# Altium schematic sheet main sheet.SchDoc
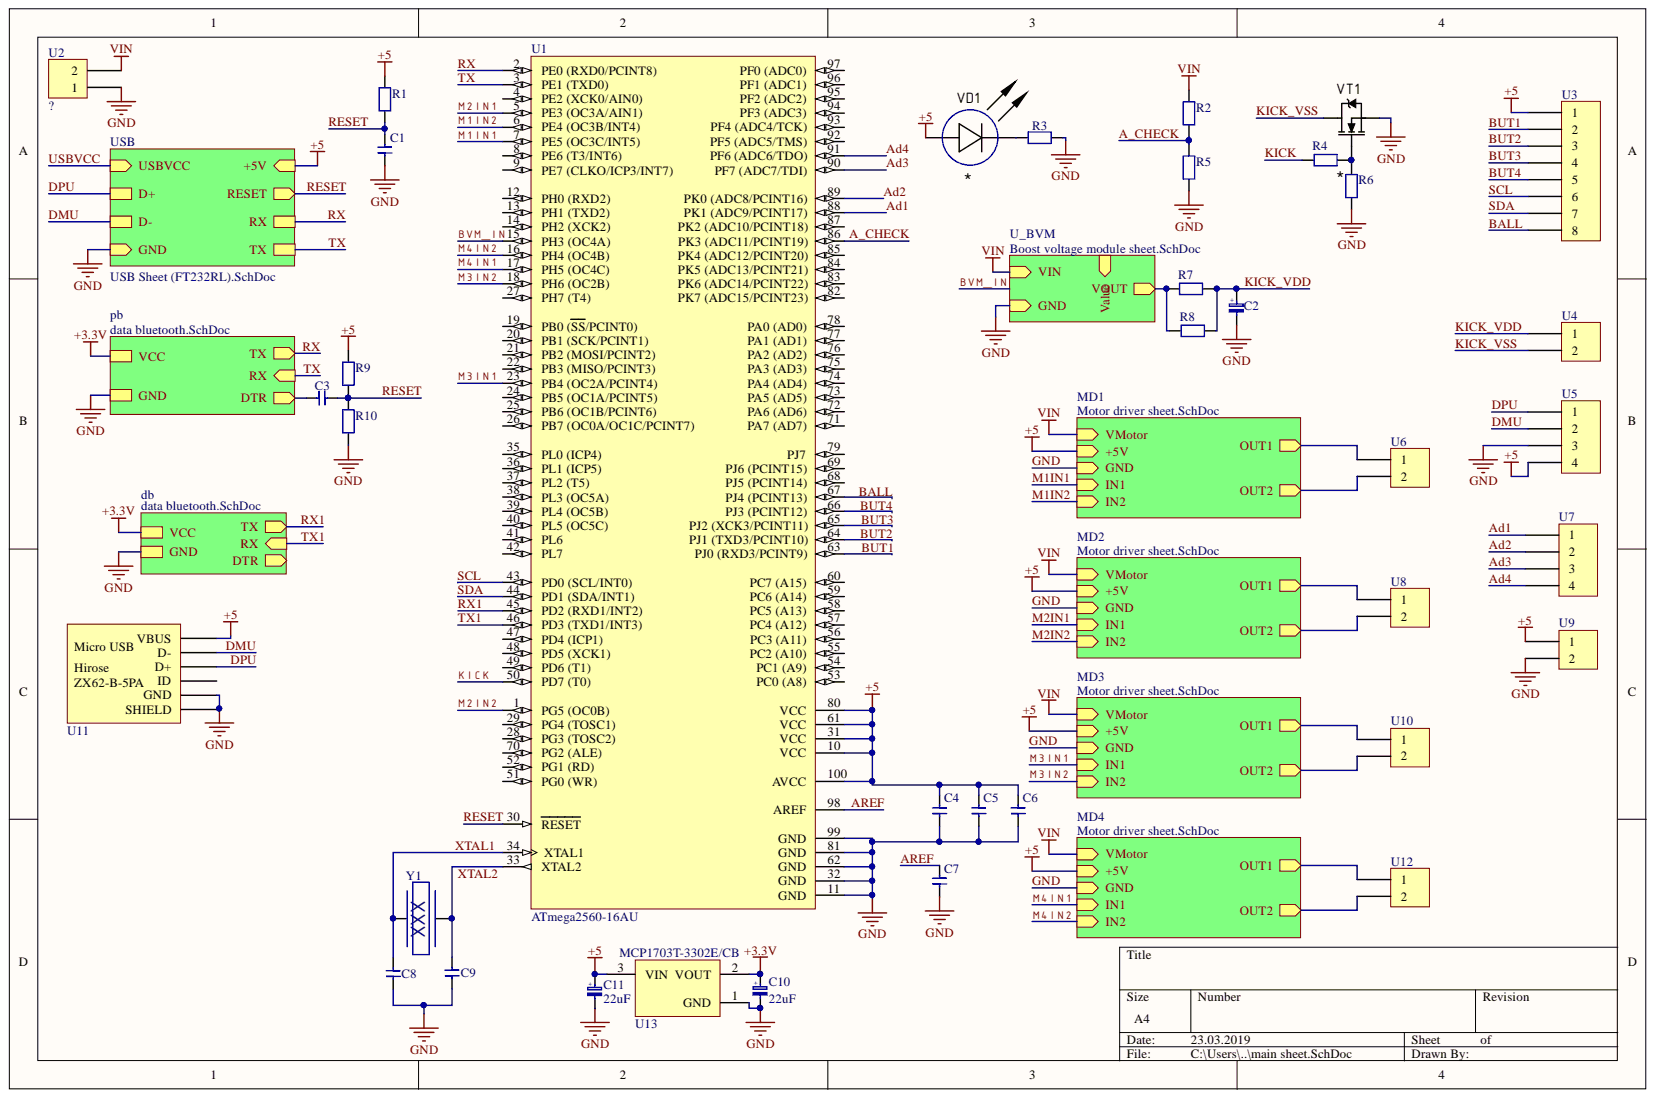
[diagram p0: main sheet.SchDoc]

## Parts
C1  =Value  [cap0603]
C2  =Value  [D18C7.5H40]
C3  =Value  [cap0603]
C4  =Value  [cap0603]
C5  =Value  [cap0603]
C6  =Value  [cap0603]
C7  =Value  [cap0603]
C8  =Value  [cap0603]
C9  =Value  [cap0603]
C10  22uF  [Tantal B]
C11  22uF  [Tantal B]
R1  =Value  [res0603]
R2  =Value  [res0805]
R3  =Value  [res0603]
R4  =Value  [res0603]
R5  =Value  [res0805]
R6  =Value  [res0603]
R7  =Value  [smd2512]
R8  =Value  [smd2512]
R9  =Value  [res0603]
R10  =Value  [res0603]
U1  ATmega2560-16AU  [100A_N]
U2  ?  [XT30]
U3  Header 8  [8x1.25]  (value not drawn)
U4  ?  [XT30]  (value not drawn)
U5  Header 4  [4x1.25]  (value not drawn)
U6  Header 2  [2x1.25]  (value not drawn)
U7  Header 4  [4x1.25]  (value not drawn)
U8  Header 2  [2x1.25]  (value not drawn)
U9  Header 2  [2x1.25]  (value not drawn)
U10  Header 2  [2x1.25]  (value not drawn)
U11  ZX62-B-5PA  [ZX62-B-5PA]
U12  Header 2  [2x1.25]  (value not drawn)
U13  MCP1703T-3302E/CB  [SOT-23A-CB3_N]
VD1  (no value)  [CH0603LB]
VT1  (no value)  [DPAK]
Y1  XXX  [QSMD3.2x2.5_A]

## Nets
+3.3V: C10.2 U13.2
+5: C4.2 C5.2 C6.2 C11.2 R1.2 R9.2 U1.10(VCC) U1.31(VCC) U1.61(VCC) U1.80(VCC) U1.100(AVCC) U3.1 U5.4 U9.1 U11.1(VBUS) U13.3 VD1.1
VIN: R2.2 U2.2
A_CHECK: R2.1 R5.2 U1.86(PK3_(ADC11/PCINT19))
Ad1: U1.88(PK1_(ADC9/PCINT17)) U7.1
Ad2: U1.89(PK0_(ADC8/PCINT16)) U7.2
Ad3: U1.90(PF7_(ADC7/TDI)) U7.3
Ad4: U1.91(PF6_(ADC6/TDO)) U7.4
AREF: C7.2 U1.98(AREF)
BALL: U1.67(PJ4_(PCINT13)) U3.8
BUT1: U1.63(PJ0_(RXD3/PCINT9)) U3.2
BUT2: U1.64(PJ1_(TXD3/PCINT10)) U3.3
BUT3: U1.65(PJ2_(XCK3/PCINT11)) U3.4
BUT4: U1.66(PJ3_(PCINT12)) U3.5
BVM_IN: U1.15(PH3_(OC4A))
DMU: U5.2 U11.2(D-)
DPU: U5.1 U11.3(D+)
GND: C1.1 C2.2 C4.1 C5.1 C6.1 C7.1 C8.1 C9.1 C10.1 C11.1 R3.2 R5.1 R6.1 R10.1 U1.11(GND) U1.32(GND) U1.62(GND) U1.81(GND) U1.99(GND) U2.1 U5.3 U9.2 U11.5(GND) U11.6(SHIELD) U13.1 VT1.3
KICK: R4.1 U1.50(PD7_(T0))
KICK_VDD: C2.1 R7.2 R8.2 U4.1
KICK_VSS: U4.2 VT1.2
M1IN1: U1.7(PE5_(OC3C/INT5))
M1IN2: U1.6(PE4_(OC3B/INT4))
M2IN1: U1.5(PE3_(OC3A/AIN1))
M2IN2: U1.1(PG5_(OC0B))
M3IN1: U1.23(PB4_(OC2A/PCINT4))
M3IN2: U1.18(PH6_(OC2B))
M4IN1: U1.17(PH5_(OC4C))
M4IN2: U1.16(PH4_(OC4B))
RESET: C1.2 C3.2 R1.1 R9.1 R10.2 U1.30(RESET)
RX: U1.2(PE0_(RXD0/PCINT8))
RX1: U1.45(PD2_(RXD1/INT2))
SCL: U1.43(PD0_(SCL/INT0)) U3.6
SDA: U1.44(PD1_(SDA/INT1)) U3.7
TX: U1.3(PE1_(TXD0))
TX1: U1.46(PD3_(TXD1/INT3))
XTAL1: C8.2 U1.34(XTAL1) Y1.1
XTAL2: C9.2 U1.33(XTAL2) Y1.2
NetC3_1: C3.1
NetR3_1: R3.1 VD1.2
NetR4_2: R4.2 R6.2 VT1.1
NetR7_1: R7.1 R8.1
NetU1_4: U1.4(PE2_(XCK0/AIN0))
NetU1_8: U1.8(PE6_(T3/INT6))
NetU1_9: U1.9(PE7_(CLKO/ICP3/INT7))
NetU1_12: U1.12(PH0_(RXD2))
NetU1_13: U1.13(PH1_(TXD2))
NetU1_14: U1.14(PH2_(XCK2))
NetU1_19: U1.19(PB0_(SS/PCINT0))
NetU1_20: U1.20(PB1_(SCK/PCINT1))
NetU1_21: U1.21(PB2_(MOSI/PCINT2))
NetU1_22: U1.22(PB3_(MISO/PCINT3))
NetU1_24: U1.24(PB5_(OC1A/PCINT5))
NetU1_25: U1.25(PB6_(OC1B/PCINT6))
NetU1_26: U1.26(PB7_(OC0A/OC1C/PCINT7))
NetU1_27: U1.27(PH7_(T4))
NetU1_28: U1.28(PG3_(TOSC2))
NetU1_29: U1.29(PG4_(TOSC1))
NetU1_35: U1.35(PL0_(ICP4))
NetU1_36: U1.36(PL1_(ICP5))
NetU1_37: U1.37(PL2_(T5))
NetU1_38: U1.38(PL3_(OC5A))
NetU1_39: U1.39(PL4_(OC5B))
NetU1_40: U1.40(PL5_(OC5C))
NetU1_41: U1.41(PL6)
NetU1_42: U1.42(PL7)
NetU1_47: U1.47(PD4_(ICP1))
NetU1_48: U1.48(PD5_(XCK1))
NetU1_49: U1.49(PD6_(T1))
NetU1_51: U1.51(PG0_(WR))
NetU1_52: U1.52(PG1_(RD))
NetU1_53: U1.53(PC0_(A8))
NetU1_54: U1.54(PC1_(A9))
NetU1_55: U1.55(PC2_(A10))
NetU1_56: U1.56(PC3_(A11))
NetU1_57: U1.57(PC4_(A12))
NetU1_58: U1.58(PC5_(A13))
NetU1_59: U1.59(PC6_(A14))
NetU1_60: U1.60(PC7_(A15))
NetU1_68: U1.68(PJ5_(PCINT14))
NetU1_69: U1.69(PJ6_(PCINT15))
NetU1_70: U1.70(PG2_(ALE))
NetU1_71: U1.71(PA7_(AD7))
NetU1_72: U1.72(PA6_(AD6))
NetU1_73: U1.73(PA5_(AD5))
NetU1_74: U1.74(PA4_(AD4))
NetU1_75: U1.75(PA3_(AD3))
NetU1_76: U1.76(PA2_(AD2))
NetU1_77: U1.77(PA1_(AD1))
NetU1_78: U1.78(PA0_(AD0))
NetU1_79: U1.79(PJ7)
NetU1_82: U1.82(PK7_(ADC15/PCINT23))
NetU1_83: U1.83(PK6_(ADC14/PCINT22))
NetU1_84: U1.84(PK5_(ADC13/PCINT21))
NetU1_85: U1.85(PK4_(ADC12/PCINT20))
NetU1_87: U1.87(PK2_(ADC10/PCINT18))
NetU1_92: U1.92(PF5_(ADC5/TMS))
NetU1_93: U1.93(PF4_(ADC4/TCK))
NetU1_94: U1.94(PF3_(ADC3))
NetU1_95: U1.95(PF2_(ADC2))
NetU1_96: U1.96(PF1_(ADC1))
NetU1_97: U1.97(PF0_(ADC0))
NetU6_1: U6.1
NetU6_2: U6.2
NetU8_1: U8.1
NetU8_2: U8.2
NetU10_1: U10.1
NetU10_2: U10.2
NetU11_4: U11.4(ID)
NetU12_1: U12.1
NetU12_2: U12.2
DTR:
USBVCC:
Value:

## Library data of the parts
C1 C3-C9 = Cap SMD: Component Kind: Standard; Library Name: AlexChistiakov.SchLib
C2 = Cap Aluminum: Component Kind: Standard; Library Name: AlexChistiakov.SchLib
C10 C11 = Cap Tantal: Component Kind: Standard; Library Name: Discrete smd.SchLib
R1-R10 = Resistor SMD: Component Kind: Standard; Library Name: AlexChistiakov.SchLib
U1 = ATmega2560-16AU: 8-bit AVR Microcontroller, 4.5-5.5V, 256KB Flash, 4KB EEPROM, 8KB SRAM, 86 GPIO pins, 100-pin TQFP, Industrial Grade (-40°C to 85°C), Pb-Free; Code_JEDEC: MS-026-AED; Component Kind: Standard; ComponentLink1Description: Manufacturer Link; ComponentLink1URL: http://www.atmel.com/products/avr/default.asp?; ComponentLink2Description: ATmega640/1280/1281/2560/2561 Datasheet; ComponentLink2URL: http://www.atmel.com/dyn/resources/prod_documents/doc2549.pdf; ComponentLink3Description: ATmega640/1280/1281/2560/2561 Summary; ComponentLink3URL: http://www.atmel.com/dyn/resources/prod_documents/2549S.pdf; ComponentLink4Description: Atmel.com Home; ComponentLink4URL: http://www.atmel.com; DatasheetVersion: revL, Aug-2007; Library Name: Atmel Microcontroller 8-Bit megaAVR.IntLib; PackageDescription: 100-Pin Thin Profile Plastic Quad Flat Pack (TQFP), 14 x 14 x 1.0 mm Body, 0.5 mm Pitch; PackageReference: 100A; PackageVersion: revC, Oct-2001; Published: 1-Apr-2010; Supplier 1: Digi-Key; Supplier 2: Farnell; Supplier 3: Farnell; Supplier 4: Mouser; Supplier Part Number 1: ATMEGA2560-16AU-ND; Supplier Part Number 2: 1288330; Supplier Part Number 3: 1661740; Supplier Part Number 4: 556-ATMEGA2560-16AU; Publisher: Altium Limited
U2 U4 = Power connectors: Component Kind: Standard; Library Name: AlexChistiakov.SchLib
U13 = MCP1703T-3302E/CB: 250 mA, 16V, Low Quiescent Current LDO Regulator, 3-Pin SOT-23A, Extended Temperature, Tape and Reel; Component Kind: Standard; ComponentLink1Description: Datasheet; ComponentLink1URL: http://ww1.microchip.com/downloads/en/DeviceDoc/22049d.pdf; ComponentLink2Description: Packaging Specification; ComponentLink2URL: http://www.microchip.com/stellent/groups/techpub_sg/documents/packagingspec/en012702.pdf; ComponentLink3Description: Microchip.com Home; ComponentLink3URL: http://www.microchip.com/; DatasheetVersion: revD, Sep-2009; Library Name: Microchip Power Mgt Line Regulator.IntLib; PackageDescription: 3-Lead Plastic Small Outline Transistor (CB) [SOT-23A]; PackageReference: SOT-23A-CB3; PackageVersion: revBB, Aug-2009; Published: 29-Jan-2009; Supplier 1: Digi-Key; Supplier 2: Digi-Key; Supplier 3: Digi-Key; Supplier 4: Farnell; Supplier Part Number 1: MCP1703T-3302E/CBTR-ND; Supplier Part Number 2: MCP1703T-3302E/CBCT-ND; Supplier Part Number 3: MCP1703T-3302E/CBDKR-ND; Supplier Part Number 4: 1439518; Publisher: Altium Limited
VD1 = LED: Component Kind: Standard; Library Name: Discrete.SchLib
VT1 = N-chanel: Mosfet; Component Kind: Standard; Library Name: Transistor.IntLib
Y1 = Crystal: Кварцевый резонатор; Component Kind: Standard; Value: XXX; Library Name: TarabusLib_KOR.SchLib
U3 = Header 8: Component Kind: Standard; Library Name: AlexChistiakov.SchLib
U5 U7 = Header 4: Component Kind: Standard; Library Name: AlexChistiakov.SchLib
U6 U8-U10 U12 = Header 2: Component Kind: Standard; Library Name: AlexChistiakov.SchLib
U11 = ZX62-B-5PA: Component Kind: Standard; Library Name: AlexChistiakov.SchLib
